# Altium schematic sheet Overview.SchDoc(Overview)
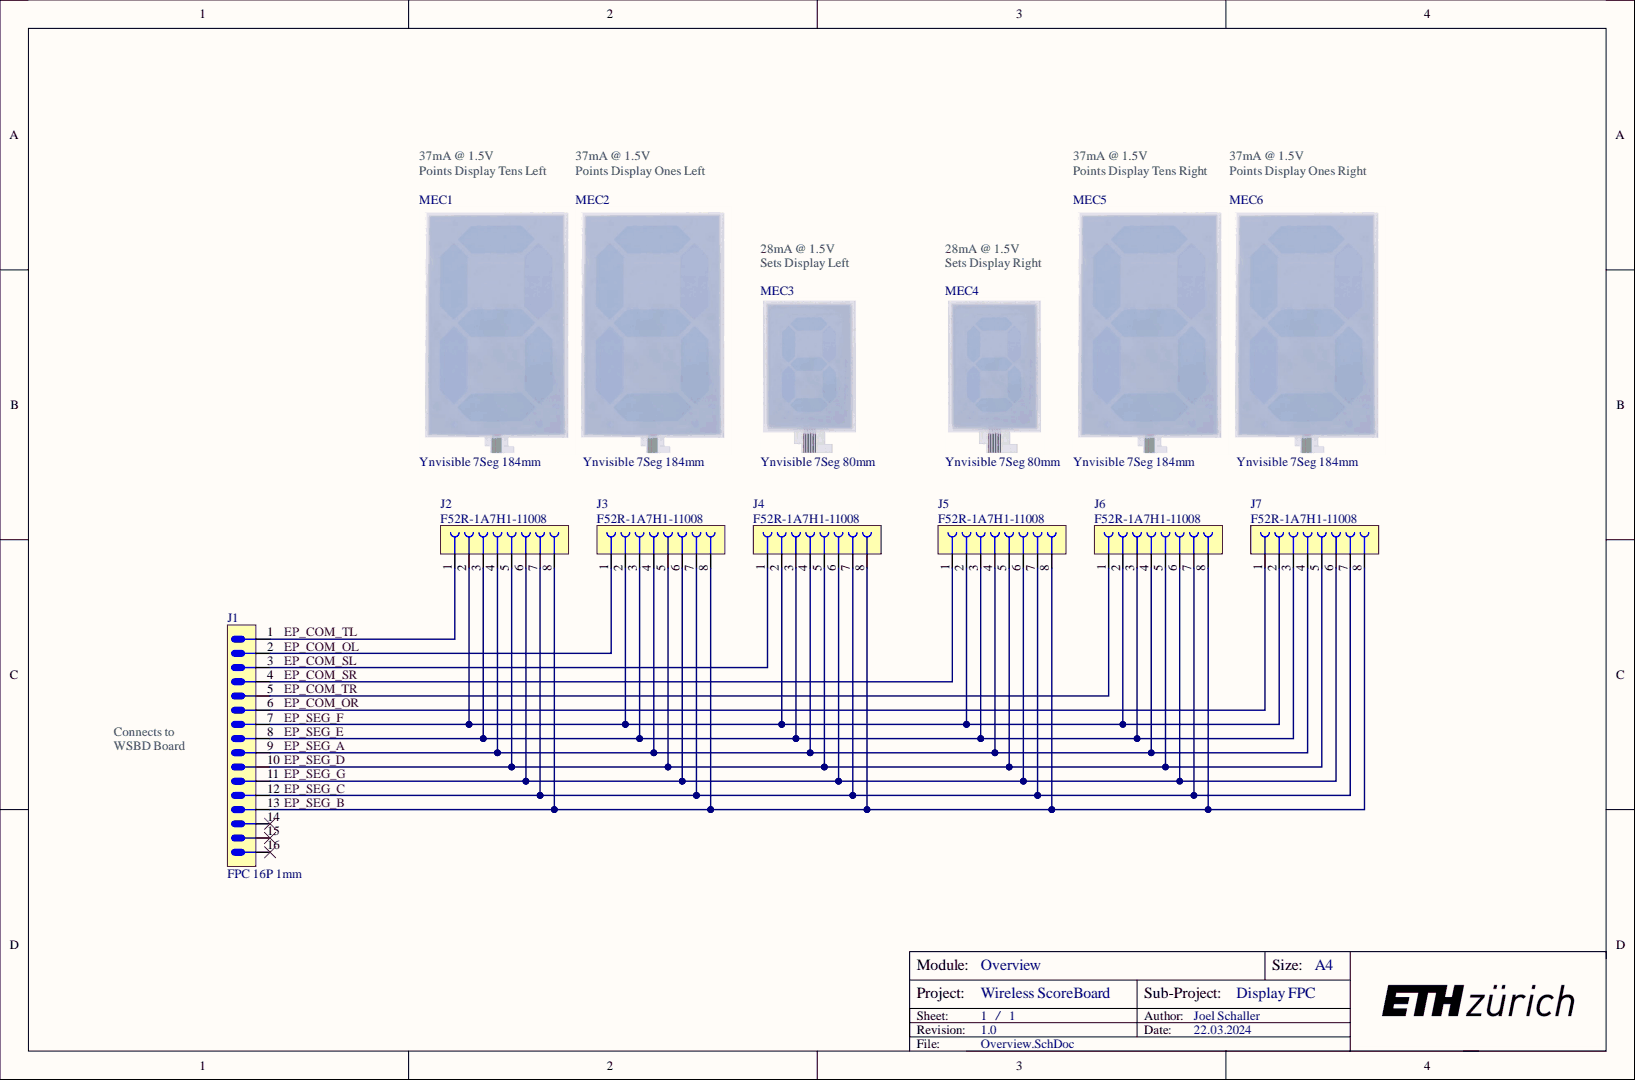
[diagram p0: Overview.SchDoc]

## Parts
J1  FPC 16P 1mm  [6pin FPC 1mm]  (no BOM)
J2  F52R-1A7H1-11008  [F52R-1A7H1-11008]
J3  F52R-1A7H1-11008  [F52R-1A7H1-11008]
J4  F52R-1A7H1-11008  [F52R-1A7H1-11008]
J5  F52R-1A7H1-11008  [F52R-1A7H1-11008]
J6  F52R-1A7H1-11008  [F52R-1A7H1-11008]
J7  F52R-1A7H1-11008  [F52R-1A7H1-11008]
MEC1  Ynvisible 7Seg 184mm  Manufacturer: Ynvisible  (mechanical)
MEC2  Ynvisible 7Seg 184mm  Manufacturer: Ynvisible  (mechanical)
MEC3  Ynvisible 7Seg 80mm  Manufacturer: Ynvisible  (mechanical)
MEC4  Ynvisible 7Seg 80mm  Manufacturer: Ynvisible  (mechanical)
MEC5  Ynvisible 7Seg 184mm  Manufacturer: Ynvisible  (mechanical)
MEC6  Ynvisible 7Seg 184mm  Manufacturer: Ynvisible  (mechanical)

## Nets
EP_COM_OL: J1.2 J3.1
EP_COM_OR: J1.6 J7.1
EP_COM_SL: J1.3 J4.1
EP_COM_SR: J1.4 J5.1
EP_COM_TL: J1.1 J2.1
EP_COM_TR: J1.5 J6.1
EP_SEG_A: J1.9 J2.4 J3.4 J4.4 J5.4 J6.4 J7.4
EP_SEG_B: J1.13 J2.8 J3.8 J4.8 J5.8 J6.8 J7.8
EP_SEG_C: J1.12 J2.7 J3.7 J4.7 J5.7 J6.7 J7.7
EP_SEG_D: J1.10 J2.5 J3.5 J4.5 J5.5 J6.5 J7.5
EP_SEG_E: J1.8 J2.3 J3.3 J4.3 J5.3 J6.3 J7.3
EP_SEG_F: J1.7 J2.2 J3.2 J4.2 J5.2 J6.2 J7.2
EP_SEG_G: J1.11 J2.6 J3.6 J4.6 J5.6 J6.6 J7.6

Not connected: J1 14 15 16

## Library data of the parts
J1 = CMP-03085-5: CONN FPC 16POS 1mm; Library Name: ETHZ Vault
J2-J7 = CMP-03084-2: CONN FPC TOP 8POS 1mm; Manufacturer: Amphenol ICC (FCI); Component Kind: Standard; Library Name: ETHZ Vault; Manufacturer Part Number: F52R-1A7H1-11008; Supplier: Digi-Key; Supplier2: Mouser; Supplier2 Part Number: 649-F52R-1A7H1-11008; Supplier Part Number: 609-F52R-1A7H1-11008CT-ND
MEC1 MEC2 MEC5 MEC6 = CMP-03086-2: EMECH SINGLE EPAPER 7SEG 184MM; Manufacturer Part Number: LARGE E-PAPER DISPLAY – SINGLE DIGIT 184MM; Library Name: ETHZ Vault; Supplier: Ynvisible Shop; Supplier Part Number: SINGLE DIGIT 184MM
MEC3 MEC4 = CMP-03087-2: EMECH SINGLE EPAPER 7SEG 80MM; Manufacturer Part Number: LARGE E-PAPER DISPLAY – SINGLE DIGIT 80MM; Library Name: ETHZ Vault; Supplier: Ynvisible Shop; Supplier Part Number: SINGLE DIGIT 80MM
